# Altium schematic sheet Main.SchDoc(Main)
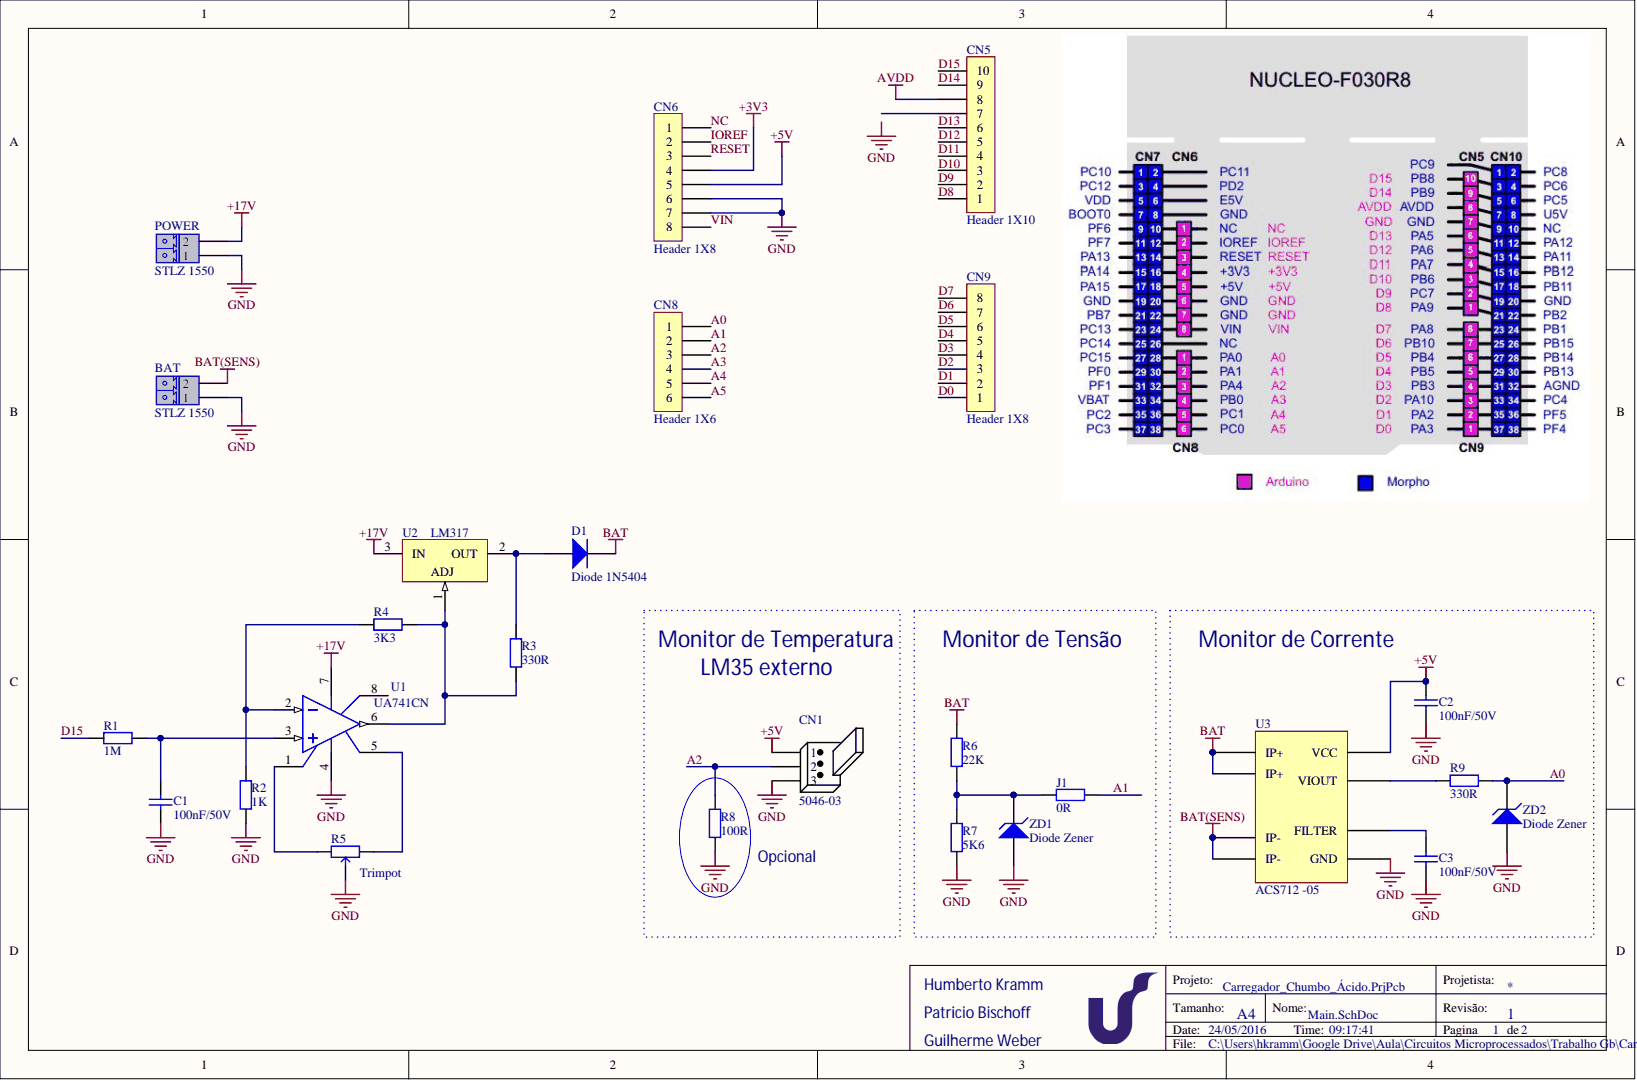
[diagram p0: Main.SchDoc]

## Parts
BAT  STLZ 1550  [STLZ 1550 H 02 PIN]
C1  value 100nF/50V  [CAP 0805]  (hidden comment: Cap.Cer. 100nF/50V SMD)
C2  value 100nF/50V  [CAP 0805]  (hidden comment: Cap.Cer. 100nF/50V SMD)  (no BOM)
C3  value 100nF/50V  [CAP 0805]  (hidden comment: Cap.Cer. 100nF/50V SMD)  (no BOM)
CN1  5046-03  [5046-03]
CN5  Header 1X10  [HEADER 1x10]
CN6  Header 1X8  [HEADER 1x8]
CN8  Header 1X6  [HEADER 1x6]
CN9  Header 1X8  [HEADER 1x8]
D1  Diode 1N5404  [DO-201AD]
J1  value 0R  [RES 0805]  (hidden comment: Res 0R SMD)
POWER  STLZ 1550  [STLZ 1550 H 02 PIN]
R1  value 1M  [RES 0805]  (hidden comment: Res 2M SMD)
R2  value 1K  [RES 0805]  (hidden comment: Res 1K SMD)
R3  value 330R  [RES 0805]  (hidden comment: Res 330R SMD)
R4  value 3K3  [RES 0805]  (hidden comment: Res 3K3 SMD)
R5  Trimpot  [3006P]
R6  value 22K  [RES 0805]  (hidden comment: Res 22K SMD)
R7  value 5K6  [RES 0805]  (hidden comment: Res 5K6 SMD)
R8  value 100R  [RES 0805]  (hidden comment: Res 100R SMD)
R9  value 330R  [RES 0805]  (hidden comment: Res 330R SMD)
U1  UA741CN  [PDIP300-P8]
U2  LM317  [TO-220A 90°]
U3  ACS712 -05  [SOIC-08]  (no BOM)
ZD1  Diode Zener  [MINI MELF]
ZD2  Diode Zener  [MINI MELF]

## Nets
+3V3: CN6.4
+5V: C2.1 CN1.1 CN6.5 U3.8(VCC)
+17V: POWER.2 U1.7 U2.3
AVDD: CN5.8
BAT: D1.2 R6.2 U3.1(IP+) U3.2(IP+)
BAT(SENS): BAT.2 U3.3(IP-) U3.4(IP-)
GND: BAT.1 C1.1 C2.2 C3.2 CN1.3 CN6.6 CN6.7 POWER.1 R2.1 R5.2 R7.1 R8.1 U1.4 U3.5(GND) ZD1.1 ZD2.1
A0: CN8.1 R9.2 ZD2.2
A1: CN8.2 J1.2
A2: CN1.2 CN8.3 R8.2
A3: CN8.4
A4: CN8.5
A5: CN8.6
D0: CN9.1
D1: CN9.2
D2: CN9.3
D3: CN9.4
D4: CN9.5
D5: CN9.6
D6: CN9.7
D7: CN9.8
D8: CN5.1
D9: CN5.2
D10: CN5.3
D11: CN5.4
D12: CN5.5
D13: CN5.6
D14: CN5.9
D15: CN5.10 R1.1
IOREF: CN6.2
NC: CN6.1
RESET: CN6.3
VIN: CN6.8
NetC1_2: C1.2 R1.2 U1.3
NetC3_1: C3.1 U3.6(FILTER)
NetD1_1: D1.1 R3.2 U2.2
NetJ1_1: J1.1 R6.1 R7.2 ZD1.2
NetR2_2: R2.2 R4.1 U1.2
NetR3_1: R3.1 R4.2 U1.6 U2.1
NetR5_1: R5.1 U1.5
NetR5_3: R5.3 U1.1
NetR9_1: R9.1 U3.7(VIOUT)

Not connected: CN5 7; U1 8

## Library data of the parts
BAT POWER = CONECTOR STLZ 1550/02G H: CONECTOR STLZ 1550 2pin Horizontal; Código: 7060; Almox.Gaia: CONECTOR STLZ 1550/02G H; Component Kind: Standard; Library Name: almox Gaia.SchLib
C1-C3 = Cap.Cer. 100nF/50V SMD: Capacitor Cerâmico; Almox.Gaia: SMD CAPACITOR CERAMICO 100nF/50V 0805; Código: 7021; LatestRevisionDate: 22-Mar-2011; LatestRevisionNote: Re-released for DXP Platform.; Library Name: almox Gaia.SchLib; PackageReference: RAD-0.3; Published: 8-Jun-2000; Signal Integrity: Cap; Simulation: CAP; Publisher: Altium Limited
  C1: Component Kind: Standard; Supplier 1: Digi-Key; Supplier 2: Newark; Supplier 3: Mouser; Supplier Part Number 1: 1276-1007-2-ND; Supplier Part Number 2: 58Y7818; Supplier Part Number 3: 581-08055C104J
CN1 = CONECTOR 5046-03: CONECTOR MOLEX KK 5045 90° 2,54mm  3 VIAS; Código: 000587; Almox.Gaia: CONECTOR 5046-03; Component Kind: Standard; LatestRevisionDate: 22-Mar-2011; LatestRevisionNote: Re-released for DXP Platform.; Signal Integrity: Connector; Library Name: almox Gaia.SchLib; Publisher: Altium Limited
CN5 = Header 1X10: Header, 10-Pin, single row, right angle; Almox.Gaia: BARRA DE PINOS 1X40; Código: 7095; Component Kind: Standard; LatestRevisionDate: 17-Jul-2002; LatestRevisionNote: Re-released for DXP Platform.; Library Name: almox Gaia.SchLib; Signal Integrity: Connector; Publisher: Altium Limited
CN6 CN9 = Header 1X8: Header, 8-Pin, single row; Almox.Gaia: BARRA DE PINOS 1X40; Código: 7094; Component Kind: Standard; LatestRevisionDate: 17-Jul-2002; LatestRevisionNote: Re-released for DXP Platform.; Library Name: almox Gaia.SchLib; Signal Integrity: Connector; Publisher: Altium Limited
CN8 = Header 1X6: Header, 6-Pin, single row; Almox.Gaia: BARRA DE PINOS 1X40; Código: 7094; Component Kind: Standard; LatestRevisionDate: 17-Jul-2002; LatestRevisionNote: Re-released for DXP Platform.; Library Name: almox Gaia.SchLib; Signal Integrity: Connector; Publisher: Altium Limited
D1 = Diode 1N5404: 3 Amp General Purpose Rectifier; Almox.Gaia: DIODO 1N5404; Código: 000604; Component Kind: Standard; ComponentLink1Description: Manufacturer Link; ComponentLink1URL: http://www.fairchildsemi.com/; ComponentLink2Description: Datasheet; ComponentLink2URL: http://www.fairchildsemi.com/ds/1N%2F1N5400.pdf; DatasheetVersion: 1998; LatestRevisionDate: 22-Mar-2011; LatestRevisionNote: Re-released for DXP Platform.; Library Name: almox Gaia.SchLib; PackageReference: DO-201AD; PackageVersion: Aug-1999; Published: 8-Jun-2000; Signal Integrity: 1N5404; Simulation: 1N5404; Publisher: Altium Limited
J1 = Res 0R SMD: Resistor; Almox.Gaia: SMD RESISTOR   0R 0805; Código: 004904; Component Kind: Standard; LatestRevisionDate: 22-Mar-2011; LatestRevisionNote: Re-released for DXP Platform.; Library Name: almox Gaia.SchLib; PackageReference: AXIAL-0.4; Published: 8-Jun-2000; Signal Integrity: Res; Simulation: RESISTOR; Supplier 1: Digi-Key; Supplier 2: Newark; Supplier 3: Mouser; Supplier Part Number 1: 311-0.0ARCT-ND; Supplier Part Number 2: 68R0285; Supplier Part Number 3: 667-ERJ-6GEY0R00V; Publisher: Altium Limited
R1 = Res 2M SMD: Resistor; Almox.Gaia: SMD RESISTOR    2M 0805; Código: 005147; Component Kind: Standard; LatestRevisionDate: 22-Mar-2011; LatestRevisionNote: Re-released for DXP Platform.; Library Name: almox Gaia.SchLib; PackageReference: AXIAL-0.4; Published: 8-Jun-2000; Signal Integrity: Res; Simulation: RESISTOR; Supplier 1: Digi-Key; Supplier 2: Newark; Supplier 3: Mouser; Supplier Part Number 1: P2.0MACT-ND; Supplier Part Number 2: 59M6868; Supplier Part Number 3: 667-ERJ-U06J205V; Publisher: Altium Limited
R2 = Res 1K SMD: Resistor; Almox.Gaia: SMD RESISTOR    1K 0805; Código: 004903; Component Kind: Standard; LatestRevisionDate: 22-Mar-2011; LatestRevisionNote: Re-released for DXP Platform.; Library Name: almox Gaia.SchLib; PackageReference: AXIAL-0.4; Published: 8-Jun-2000; Signal Integrity: Res; Simulation: RESISTOR; Supplier 1: Digi-Key; Supplier 2: Newark; Supplier 3: Mouser; Supplier Part Number 1: P1.00KCCT-ND; Supplier Part Number 2: 65J1337; Supplier Part Number 3: 667-ERJ-6GEYJ102V; Publisher: Altium Limited
R3 R9 = Res 330R SMD: Resistor; Almox.Gaia: SMD RESISTOR 330R 0805; Código: 004908; Component Kind: Standard; LatestRevisionDate: 22-Mar-2011; LatestRevisionNote: Re-released for DXP Platform.; Library Name: almox Gaia.SchLib; PackageReference: SDM; Published: 8-Jun-2000; Signal Integrity: Res; Simulation: RESISTOR; Supplier 1: Digi-Key; Supplier 2: Newark; Supplier 3: Mouser; Supplier Part Number 1: RMCF0805JT330RTR-ND; Supplier Part Number 2: 58K4809; Supplier Part Number 3: 71-CRCW0805-330-E3; Publisher: Altium Limited
R4 = Res 3K3 SMD: Resistor; Almox.Gaia: SMD RESISTOR    3K3 0805; Código: 005136; Component Kind: Standard; LatestRevisionDate: 22-Mar-2011; LatestRevisionNote: Re-released for DXP Platform.; Library Name: almox Gaia.SchLib; PackageReference: AXIAL-0.4; Published: 8-Jun-2000; Signal Integrity: Res; Simulation: RESISTOR; Supplier 1: Digi-Key; Supplier 2: Newark; Supplier 3: Mouser; Supplier Part Number 1: RMCF0805JT3K30TR-ND; Supplier Part Number 2: 68R0241; Supplier Part Number 3: 71-CRCW0805-3.3K-E3; Publisher: Altium Limited
R5 = Trimpot: Variable Resistor; Almox.Gaia: TRIMPOT 10K VERTIVAL; Código: 7273; Set Position: 0.5; Sim Note: Enter the Resistance value and the set point into parameter fields.; Component Kind: Standard; LatestRevisionDate: 17-Jul-2002; LatestRevisionNote: Re-released for DXP Platform.; Library Name: almox Gaia.SchLib; PackageReference: VR3; Published: 24-Mar-1999; Signal Integrity: Res Tap; Simulation: POT; Publisher: Altium Limited
R6 = Res 22K SMD: Resistor; Almox.Gaia: SMD RESISTOR   22K 0805; Código: 004907; Component Kind: Standard; LatestRevisionDate: 22-Mar-2011; LatestRevisionNote: Re-released for DXP Platform.; Library Name: almox Gaia.SchLib; PackageReference: AXIAL-0.4; Published: 8-Jun-2000; Signal Integrity: Res; Simulation: RESISTOR; Supplier 1: Digi-Key; Supplier 2: Newark; Supplier 3: Mouser; Supplier Part Number 1: RMCF0805JT22K0TR-ND; Supplier Part Number 2: 59M6874; Supplier Part Number 3: 667-ERJ-6GEYJ223V; Publisher: Altium Limited
R7 = Res 5K6 SMD: Resistor; Almox.Gaia: SMD RESISTOR    5K6 0805; Código: 005142; Component Kind: Standard; LatestRevisionDate: 22-Mar-2011; LatestRevisionNote: Re-released for DXP Platform.; Library Name: almox Gaia.SchLib; PackageReference: AXIAL-0.4; Published: 8-Jun-2000; Signal Integrity: Res; Simulation: RESISTOR; Supplier 1: Digi-Key; Supplier 2: Newark; Supplier 3: Mouser; Supplier Part Number 1: 541-5.60KCCT-ND; Supplier Part Number 2: 33C6610; Supplier Part Number 3: 667-ERJ-6GEYJ562V; Publisher: Altium Limited
R8 = Res 100R SMD: Resistor; Almox.Gaia: SMD RESISTOR 100R 0805; Código: 004906; Component Kind: Standard; LatestRevisionDate: 22-Mar-2011; LatestRevisionNote: Re-released for DXP Platform.; Library Name: almox Gaia.SchLib; PackageReference: AXIAL-0.4; Published: 8-Jun-2000; Signal Integrity: Res; Simulation: RESISTOR; Supplier 1: Digi-Key; Supplier 2: Newark; Supplier 3: Mouser; Supplier Part Number 1: RMCF0805JT100RTR-ND; Supplier Part Number 2: 79M6015; Supplier Part Number 3: 71-CRCW0805-100-E3; Publisher: Altium Limited
U1 = UA741CN: General-Purpose Single Operational Amplifier; Code_JEDEC: MO-001-AN; Component Kind: Standard; ComponentLink1Description: Manufacturer Link; ComponentLink1URL: http://www.st.com/; ComponentLink2Description: Datasheet; ComponentLink2URL: http://www.st.com/stonline/products/literature/ds/5304/ua741.pdf; DatasheetVersion: Oct-1997; LatestRevisionDate: 06-Jun-2005; LatestRevisionNote: Stylized 3D Model Added.; Library Name: ST Operational Amplifier.IntLib; PackageDescription: DIP; 8 Leads; Row Spacing 7.62 mm; Pitch 2.54 mm; PackageReference: DIP8; PCB3D: DIP8; Published: 24-Aug-2001; Simulation: UA741; Publisher: Altium Limited
U2 = LM317: Positive Voltage Regulator; Almox.Gaia: CI 7812; Código: 000579; Note: Tab is connected to pin 3 (GND).; Component Kind: Standard; ComponentLink1Description: Manufacturer Link; ComponentLink1URL: http://www.st.com/; DatasheetVersion: Dec-1998; LatestRevisionDate: 17-Jul-2002; LatestRevisionNote: Re-released for DXP Platform.; Library Name: almox Gaia.SchLib; PackageDescription: TO, Thru-Hole, Vertical, Heatsink Mounted; 3 In-Line Leads; Pitch 2.54 mm; Pin sequence 1, 3, 2; PackageReference: TO220ABN; PackageVersion: Jun-1998; Published: 24-Aug-2001; Publisher: Altium Limited
U3 = ACS712 -05: Sensor de Efeito Hall; Almox.Gaia: CI ACS712 -05; Código: 7003; DatasheetVersion: ver 1.7, Feb-2005; LatestRevisionDate: 13-Apr-2006; LatestRevisionNote: IPC-7351 Footprint Added.; Library Name: almox Gaia.SchLib; PackageReference: 8-pin SOIC; PackageVersion: Mar-2004; Published: 6-Oct-2005; Publisher: Altium Limited
ZD1 ZD2 = Diode Zener SMD 4,7V: Zener Diode; Almox.Gaia: SMD DIODO ZENER 4,7V; Código: 7388; Component Kind: Standard; LatestRevisionDate: 17-Jul-2002; LatestRevisionNote: Re-released for DXP Platform.; Library Name: almox Gaia.SchLib; PackageReference: DIODE-0.7; Published: 8-Jun-2000; Signal Integrity: D Zener; Simulation: ZENER; Supplier 1: Digi-Key; Supplier 2: Newark; Supplier 3: Mouser; Supplier Part Number 1: 568-8216-2-ND; Supplier Part Number 2: 70R4020; Supplier Part Number 3: 833-DL5230B-TP; Publisher: Altium Limited
